# Altium schematic sheet Kontrollerkort_top.SchDoc(Kontrollerkort_top)
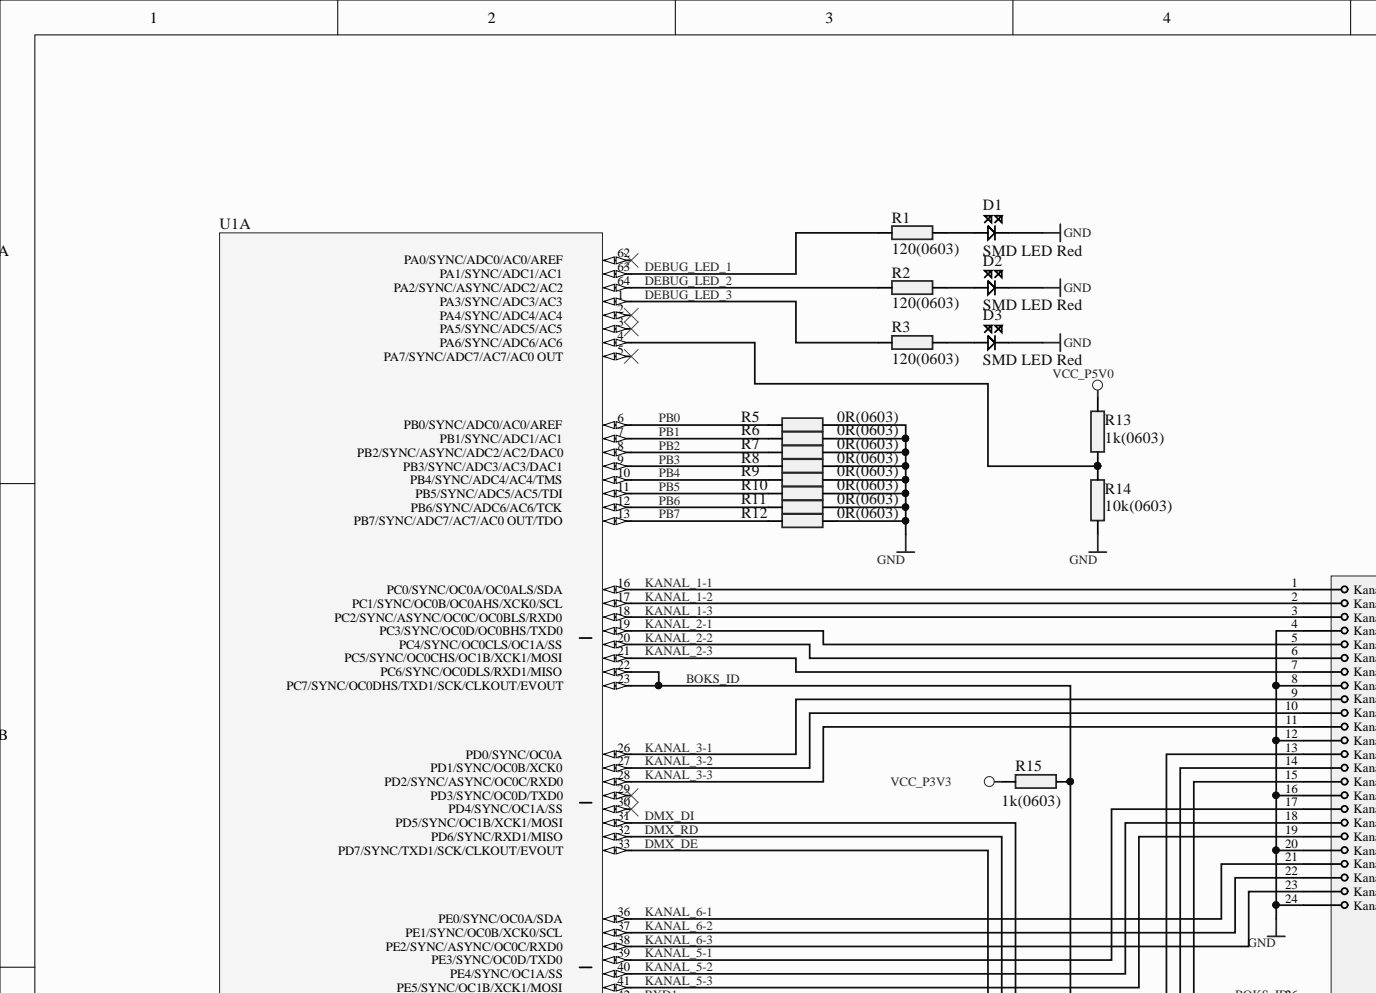
[diagram p0: Kontrollerkort_top.SchDoc, top left]
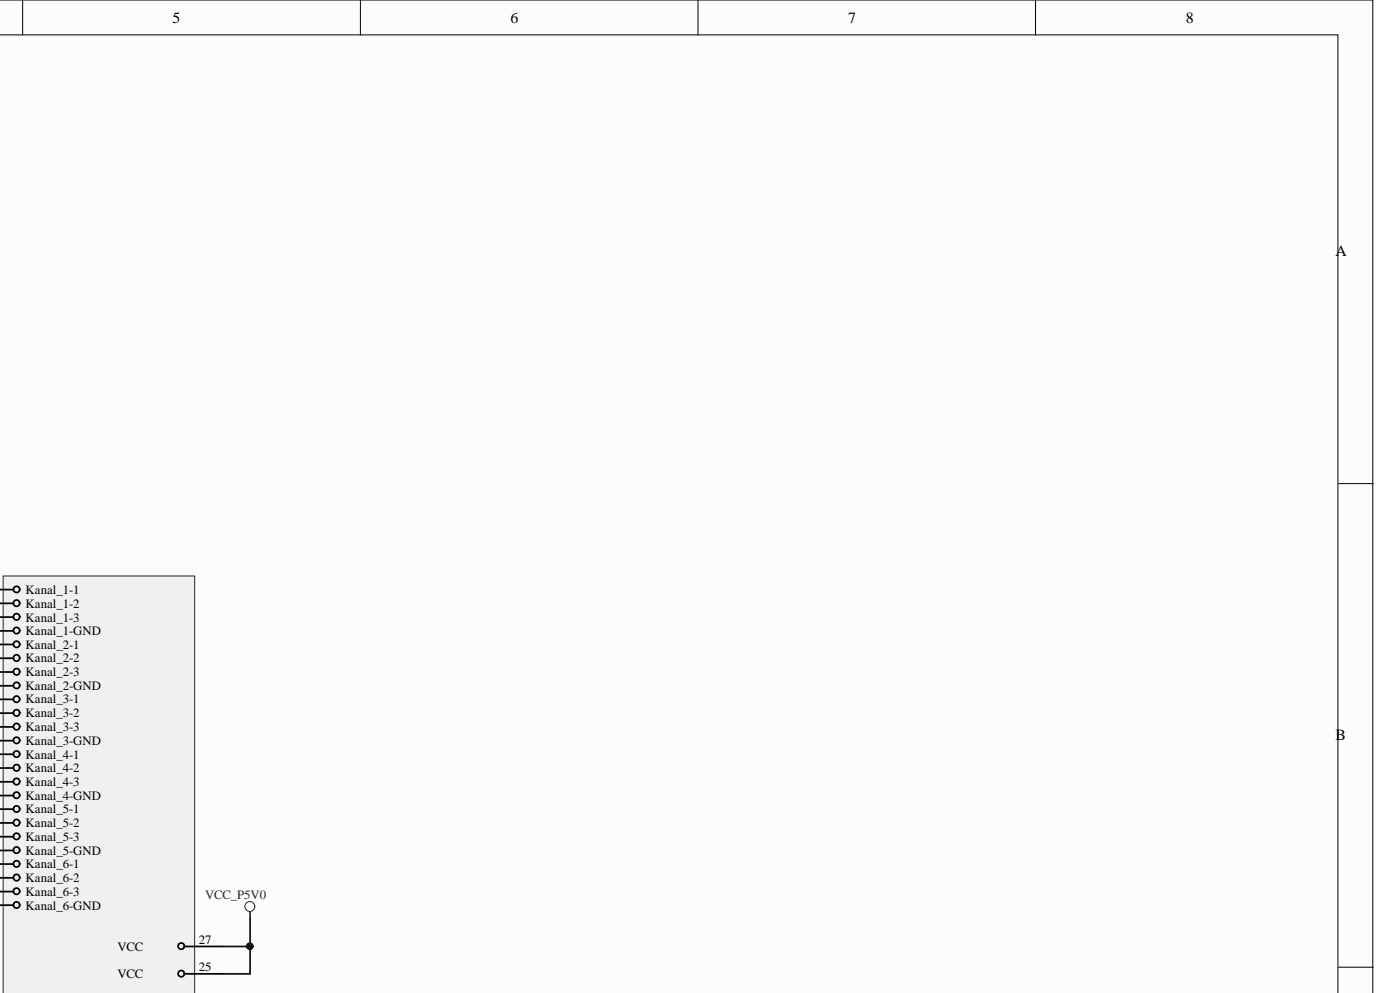
[diagram p1: Kontrollerkort_top.SchDoc, top right]
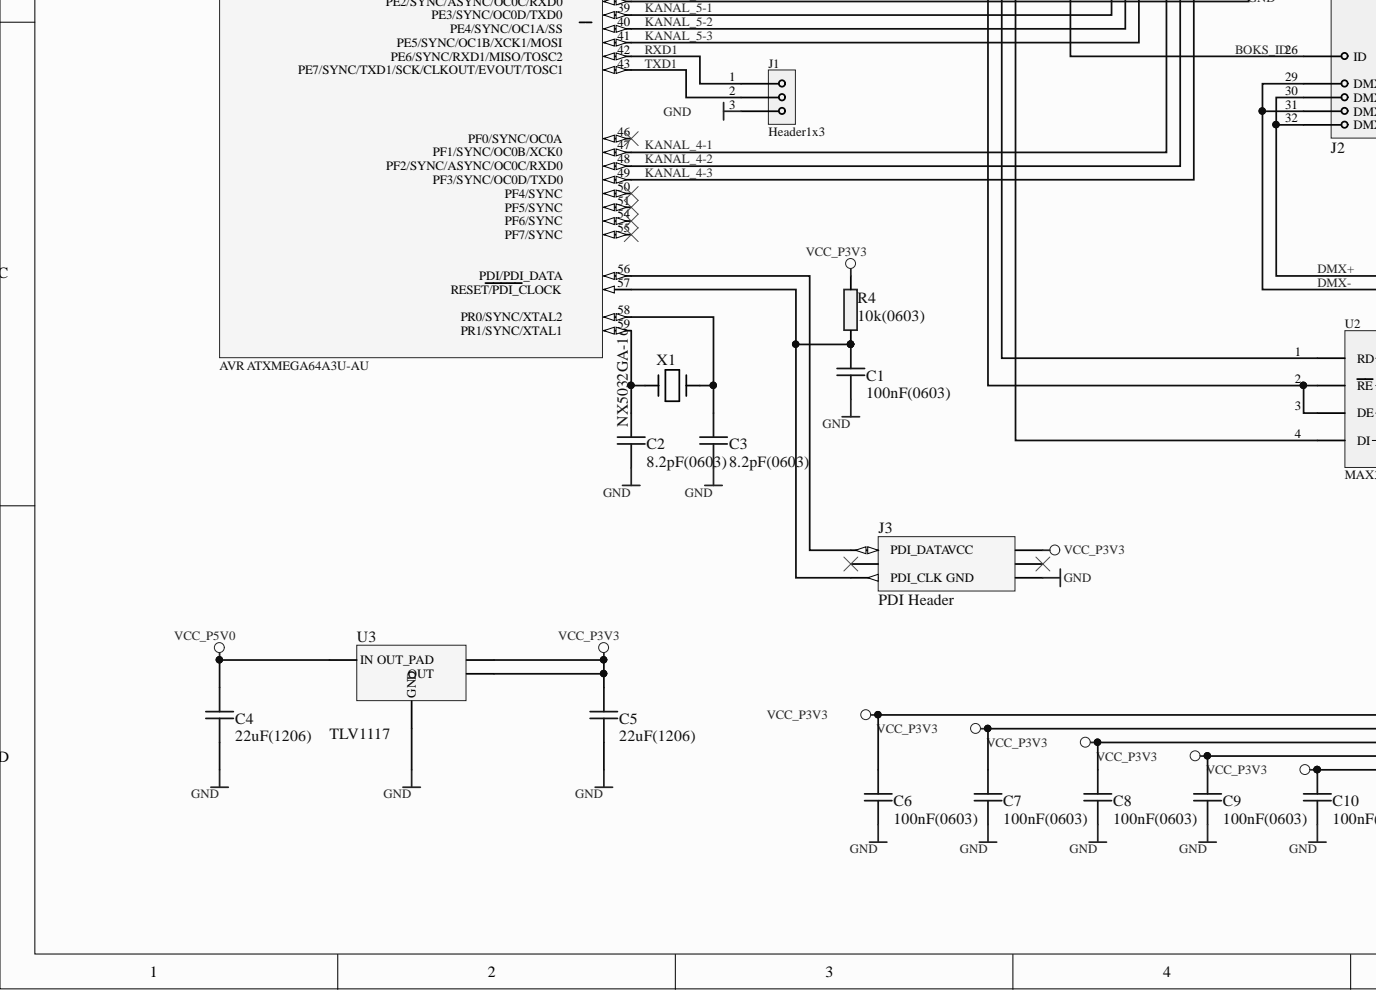
[diagram p2: Kontrollerkort_top.SchDoc, bottom left]
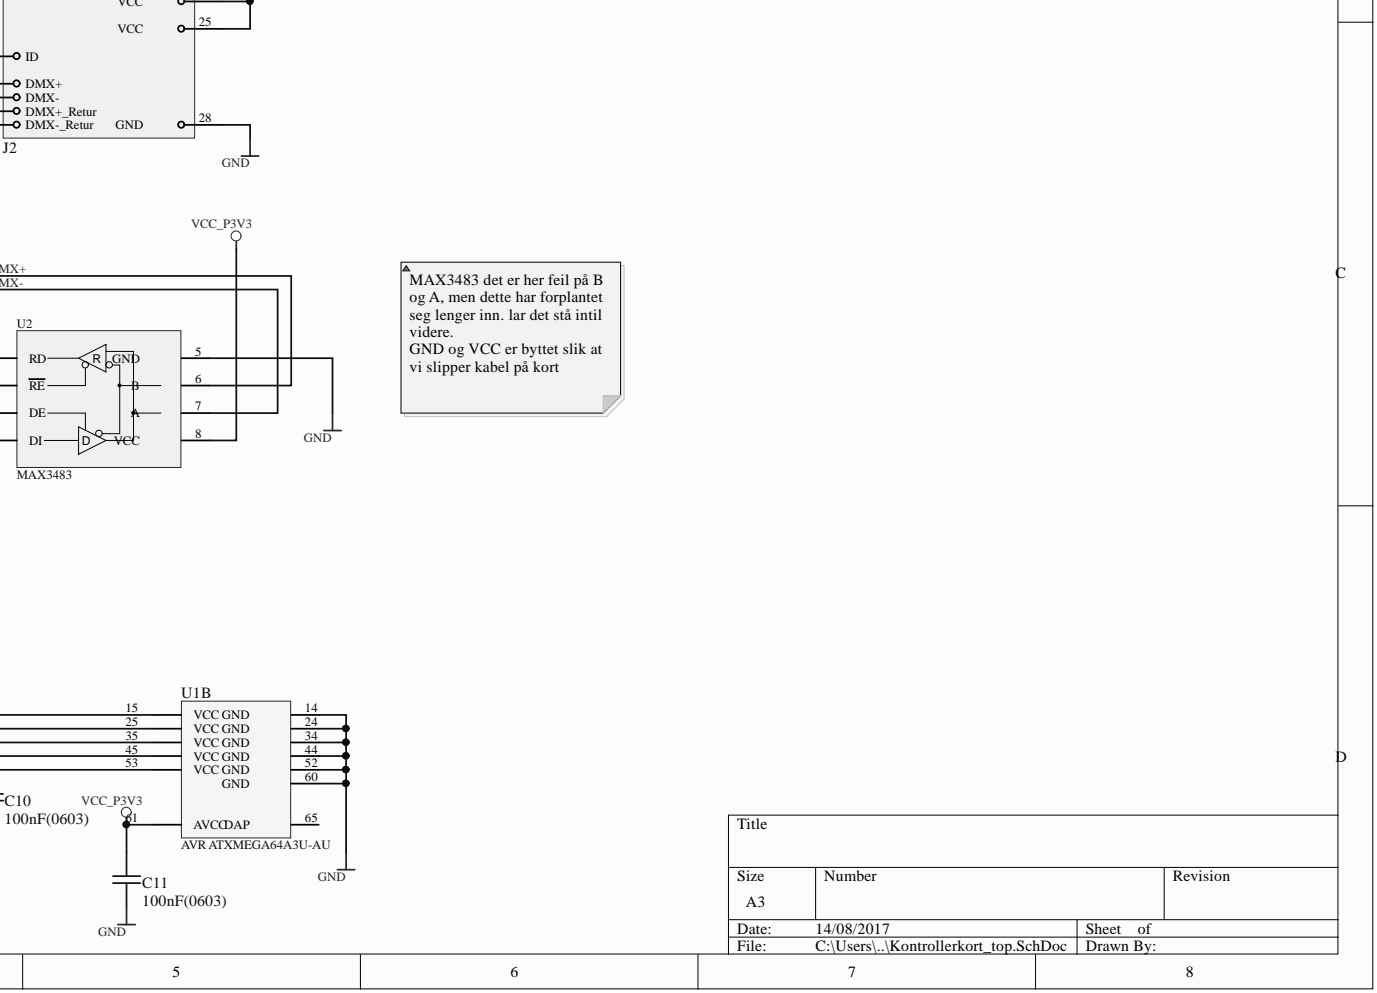
[diagram p3: Kontrollerkort_top.SchDoc, bottom right]

## Parts
C1  100nF(0603)  [CAPC0603(1608)55_N]
C2  8.2pF(0603)  [CAPC0603(1608)55_N]
C3  8.2pF(0603)  [CAPC0603(1608)55_N]
C4  22uF(1206)  [CAPC1206(3216)170_N]
C5  22uF(1206)  [CAPC1206(3216)170_N]
C6  100nF(0603)  [CAPC0603(1608)55_N]
C7  100nF(0603)  [CAPC0603(1608)55_N]
C8  100nF(0603)  [CAPC0603(1608)55_N]
C9  100nF(0603)  [CAPC0603(1608)55_N]
C10  100nF(0603)  [CAPC0603(1608)55_N]
C11  100nF(0603)  [CAPC0603(1608)55_N]
D1  SMD LED Red  [I_LEDC0603(1608)_N]
D2  SMD LED Red  [I_LEDC0603(1608)_N]
D3  SMD LED Red  [I_LEDC0603(1608)_N]
J1  Header1x3  [HDRV3W64P254_1X3_762X254X900B]
J2  Lysreklameparalellbusskontakt2015  [HDRRA32W64P254_2X16_4064X1260X600B]  (value not drawn)
J3  PDI Header  [HEADER_3x2]
R1  120(0603)  [RESC0603(1608)_N]
R2  120(0603)  [RESC0603(1608)_N]
R3  120(0603)  [RESC0603(1608)_N]
R4  10k(0603)  [RESC0603(1608)_N]
R5  0R(0603)  [RESC0603(1608)_N]
R6  0R(0603)  [RESC0603(1608)_N]
R7  0R(0603)  [RESC0603(1608)_N]
R8  0R(0603)  [RESC0603(1608)_N]
R9  0R(0603)  [RESC0603(1608)_N]
R10  0R(0603)  [RESC0603(1608)_N]
R11  0R(0603)  [RESC0603(1608)_N]
R12  0R(0603)  [RESC0603(1608)_N]
R13  1k(0603)  [RESC0603(1608)_N]
R14  10k(0603)  [RESC0603(1608)_N]
R15  1k(0603)  [RESC0603(1608)_N]
U1  AVR ATXMEGA64A3U-AU  [TQFP64]  (parts A,B)
U2  MAX3483  [SOIC-8-WIDE]
U3  TLV1117  [SOT230P700X180-4N]
X1  NX5032GA-16  [CRYSTAL-ABM7]

## Nets
GND: C1.1 C2.1 C3.1 C4.1 C5.1 C6.1 C7.1 C8.1 C9.1 C10.1 C11.1 D1.2 D2.2 D3.2 J1.3 J2.4(Kanal_1-GND) J2.8(Kanal_2-GND) J2.12(Kanal_3-GND) J2.16(Kanal_4-GND) J2.20(Kanal_5-GND) J2.24(Kanal_6-GND) J2.28 J3.6 R5.2 R6.2 R7.2 R8.2 R9.2 R10.2 R11.2 R12.2 R14.1 U1.14 U1.24 U1.34 U1.44 U1.52 U1.60 U2.5 U3.1(GND)
VCC_P3V3: C5.2 C6.2 C7.2 C8.2 C9.2 C10.2 C11.2 J3.2 R4.2 R15.2 U1.15(VCC_GND) U1.25(VCC_GND) U1.35(VCC_GND) U1.45(VCC_GND) U1.53(VCC_GND) U1.61(AVCC) U2.8 U3.2 U3.4
VCC_P5V0: C4.2 J2.25 J2.27 R13.2 U3.3(IN_OUT_PAD)
BOKS_ID: J2.26(ID) R15.1 U1.22 U1.23
DEBUG_LED_1: R1.1 U1.63
DEBUG_LED_2: R2.1 U1.64
DEBUG_LED_3: R3.1 U1.1
DMX+: J2.30(DMX-) J2.32(DMX-_Retur) U2.6
DMX-: J2.29(DMX+) J2.31(DMX+_Retur) U2.7
DMX_DE: U1.33 U2.2(RE) U2.3(DE)
DMX_DI: U1.31 U2.4(DI)
DMX_RD: U1.32 U2.1(RD)
KANAL_1-1: J2.1(Kanal_1-1) U1.16
KANAL_1-2: J2.2(Kanal_1-2) U1.17
KANAL_1-3: J2.3(Kanal_1-3) U1.18
KANAL_2-1: J2.5(Kanal_2-1) U1.19
KANAL_2-2: J2.6(Kanal_2-2) U1.20
KANAL_2-3: J2.7(Kanal_2-3) U1.21
KANAL_3-1: J2.9(Kanal_3-1) U1.26
KANAL_3-2: J2.10(Kanal_3-2) U1.27
KANAL_3-3: J2.11(Kanal_3-3) U1.28
KANAL_4-1: J2.13(Kanal_4-1) U1.47
KANAL_4-2: J2.14(Kanal_4-2) U1.48
KANAL_4-3: J2.15(Kanal_4-3) U1.49
KANAL_5-1: J2.17(Kanal_5-1) U1.39
KANAL_5-2: J2.18(Kanal_5-2) U1.40
KANAL_5-3: J2.19(Kanal_5-3) U1.41
KANAL_6-1: J2.21(Kanal_6-1) U1.36
KANAL_6-2: J2.22(Kanal_6-2) U1.37
KANAL_6-3: J2.23(Kanal_6-3) U1.38
PB0: R5.1 U1.6
PB1: R6.1 U1.7
PB2: R7.1 U1.8
PB3: R8.1 U1.9
PB4: R9.1 U1.10
PB5: R10.1 U1.11
PB6: R11.1 U1.12
PB7: R12.1 U1.13
RXD1: J1.1 U1.42
TXD1: J1.2 U1.43
NetC1_2: C1.2 J3.5 R4.1 U1.57
NetC2_2: C2.2 U1.59 X1.1
NetC3_2: C3.2 U1.58 X1.2
NetD1_1: D1.1 R1.2
NetD2_1: D2.1 R2.2
NetD3_1: D3.1 R3.2
NetJ3_1: J3.1(PDI_DATAVCC) U1.56
NetR13_1: R13.1 R14.2 U1.4

Not connected: J3 3 4; U1 2 3 5 29 30 46 50 51 54 55 62 65

## Library data of the parts
C1 C6-C11 = 100nF(0603): 100nF 0603 5% X7R 50V; Database Table Name: altium_Kondensatorer; id: 3028; nokkelord: Kondensator, Capacitor, CAP; pakke_opprettet_av: 815; pakketype: 93; pris: 1; Component Kind: Standard; Library Name: OVLib.SVNDbLib; navn: 100nF(0603); pakke_opprettet: 18.02.2015 14:18:13; symbol_opprettet: 14.11.2014 20:19:34; symbol_opprettet_av: 815
C2 C3 = 8.2pF(0603): 0603 5% NP0 50V; Database Table Name: altium_Kondensatorer; id: 3032; nokkelord: Kondensator, Capacitor, CAP; pakke_opprettet_av: 815; pakketype: 93; pris: 1; Component Kind: Standard; Library Name: OVLib.SVNDbLib; navn: 8.2pF(0603); pakke_opprettet: 18.02.2015 14:18:13; symbol_opprettet: 14.11.2014 20:19:34; symbol_opprettet_av: 815
C4 C5 = 22uF(1206): 1206 10% Tantalum 10V; Database Table Name: altium_Kondensatorer; id: 3049; leverandor: Farnell; leverandor_varenr: 1457420; nokkelord: Capacitor Cap Kondis; pakke_opprettet_av: 815; pakketype: 95; pris: 3; produsent: Kemet; Component Kind: Standard; Library Name: OVLib.SVNDbLib; navn: 22uF(1206); pakke_opprettet: 11.02.2015 13:21:22; symbol_opprettet: 14.11.2014 20:19:34; symbol_opprettet_av: 815
D1-D3 = SMD LED Red: SMD; antall: 50; Database Table Name: altium; dybde: 2; hylle: 13; id: 2209; kolonne: 0; lager_type: Fremlager; leverandor: Farnell; leverandor_varenr: 8554641; pakke_opprettet_av: 815; pakketype: 93; pris: 1; produsent: Avago; rom: OV; Component Kind: Standard; Library Name: OVLib.SVNDbLib; navn: SMD LED Red; nokkelord: SMD; pakke_opprettet: 06.07.2014 18:55:44; rad: 0; symbol_opprettet: 06.07.2014 18:59:47; symbol_opprettet_av: 815
J1 = Header 1x3: 2,54mm pitch; Database Table Name: altium; id: 2430; pakke_opprettet_av: 815; pakketype: 92; pris: 2; Component Kind: Standard; Library Name: OVLib.SVNDbLib; navn: Header 1x3; pakke_opprettet: 13.11.2014 12:31:03; symbol_opprettet: 13.11.2014 10:59:05; symbol_opprettet_av: 815
J3 = PDI-HEADER: PDI Xmega programming header; Database Table Name: altium; id: 2407; leverandor: Farnell; leverandor_varenr: Derp; pakke_opprettet_av: 774; pakketype: 92; pris: 3; produsent: OV; Component Kind: Standard; Library Name: OVLib.SVNDbLib; navn: PDI-HEADER; nokkelord: PDI Xmega programming; pakke_opprettet: 23.08.2014 13:37:50; symbol_opprettet: 23.08.2014 13:38:30; symbol_opprettet_av: 774
R1-R3 = 3050: 120R 0603 0.1W 1% Thick film resistor; Database Table Name: altium_Motstander; pakke_opprettet_av: 815; pakketype: 93; pris: 0; symbol_opprettet_av: oystesm; Component Kind: Standard; id: 3050; Library Name: OVLib.SVNDbLib; navn: 120(0603); nokkelord: Resistor; pakke_opprettet: 08.07.2014 21:15:30; symbol_opprettet: 08.07.2014 21:16:33
R4 = 2444: 10k 0603 0.1W 1% Thick film resistor; antall: 100; Database Table Name: altium_Motstander; dybde: 72; hylle: 6; kolonne: 0; lager_type: Fremlager; nokkelord: Resistor Motstand; pakke_opprettet_av: 815; pakketype: 93; rad: -1; rom: OV; symbol_opprettet_av: oystesm; Component Kind: Standard; id: 2444; Library Name: OVLib.SVNDbLib; navn: 10k(0603); pakke_opprettet: 08.07.2014 21:15:30; pris: 0; symbol_opprettet: 08.07.2014 21:16:33
R5-R12 = 3186: 0.1W 1% Thick film resistor; Database Table Name: altium_Motstander; nokkelord: Resistor Motstand Jumper Lask; pakke_opprettet_av: 815; pakketype: 93; pris: 0; produsent: Multikomp; symbol_opprettet_av: oystesm; Component Kind: Standard; id: 3186; Library Name: OVLib.SVNDbLib; navn: 0R(0603); pakke_opprettet: 08.07.2014 21:15:30; symbol_opprettet: 08.07.2014 21:16:33
R13 = 3029: 0603 0.1W 1% Thick film resistor; Database Table Name: altium_Motstander; leverandor: Farnell; leverandor_varenr: 2447272; nokkelord: Resistor Motstand; pakke_opprettet_av: 815; pakketype: 93; pris: 0; produsent: Multikomp; symbol_opprettet_av: oystesm; Component Kind: Standard; id: 3029; Library Name: OVLib.SVNDbLib; navn: 1k(0603); pakke_opprettet: 08.07.2014 21:15:30; symbol_opprettet: 08.07.2014 21:16:33
R14 = 2444: 10k 0603 0.1W 1% Thick film resistor; antall: 100; Database Table Name: altium_Motstander; dybde: 72; hylle: 6; kolonne: 0; lager_type: Fremlager; leverandor: Farnell; leverandor_varenr: 2447230; nokkelord: Resistor Motstand; pakke_opprettet_av: 815; pakketype: 93; produsent: Multikomp; rad: -1; rom: OV; symbol_opprettet_av: oystesm; Component Kind: Standard; id: 2444; Library Name: OVLib.SVNDbLib; navn: 10k(0603); pakke_opprettet: 08.07.2014 21:15:30; pris: 0; symbol_opprettet: 08.07.2014 21:16:33
R15 = 3029: 0603 0.1W 1% Thick film resistor; Database Table Name: altium_Motstander; nokkelord: Resistor Motstand; pakke_opprettet_av: 815; pakketype: 93; pris: 0; produsent: Multikomp; symbol_opprettet_av: oystesm; Component Kind: Standard; id: 3029; Library Name: OVLib.SVNDbLib; navn: 1k(0603); pakke_opprettet: 08.07.2014 21:15:30; symbol_opprettet: 08.07.2014 21:16:33
U1 = AVR ATXMEGA64A3U-AU: Mikrokontroller; antall: 40; Database Table Name: altium; dybde: 0; id: 2105; kolonne: 1; lager_type: Fremlager; pakke_opprettet_av: 815; pakketype: 45; produsent: Atmel; rad: 4; rom: OV; Component Kind: Standard; hylle: 0; Library Name: OVLib.SVNDbLib; navn: AVR ATXMEGA64A3U-AU; pakke_opprettet: 13.07.2014 19:45:53; pris: 0; symbol_opprettet: 07.05.2015 23:14:48; symbol_opprettet_av: 815
U2 = MAX3483: 3.3V-Powered, 10Mbps and Slew-Rate-Limited True RS-485/RS-422 Transceivers; antall: 40; Database Table Name: altium; dybde: 0; hylle: 2; id: 3048; kolonne: 4; lager_type: Fremlager; leverandor: Farnell; leverandor_varenr: 1296640; nokkelord: RS485; pakke_opprettet_av: 815; pakketype: 53; pris: 22; produsent: Exar; rom: OV; Component Kind: Standard; Library Name: OVLib.SVNDbLib; navn: MAX3483; pakke_opprettet: 28.06.2014 18:39:37; rad: 4; symbol_opprettet: 07.05.2015 21:29:51; symbol_opprettet_av: 815
U3 = TLV1117: 3,3V Low dropout regulator; antall: 10; Database Table Name: altium; dybde: 0; hylle: 9; id: 2386; lager_type: Fremlager; leverandor: Farnell; leverandor_varenr: 1494942; nokkelord: ldo; pakke_opprettet_av: 774; pakketype: 77; pris: 5; produsent: Texas Instruments; rad: 2; rom: OV; Component Kind: Standard; kolonne: 0; Library Name: OVLib.SVNDbLib; navn: TLV1117; pakke_opprettet: 11.07.2014 22:00:23; symbol_opprettet: 11.07.2014 22:01:42; symbol_opprettet_av: 774
X1 = NX5032GA-16: Crystal 16 MHz; antall: 42; Database Table Name: altium; dybde: 1; hylle: 2; id: 1594; kolonne: 3; lager_type: Fremlager; leverandor: DigiKey; leverandor_varenr: 644-1135-1-ND; nokkelord: xtal, oscillator; pakke_opprettet_av: 774; pakketype: 101; pris: 5; produsent: NDK; rad: 0; rom: OV; Component Kind: Standard; Library Name: OVLib.SVNDbLib; navn: NX5032GA-16; pakke_opprettet: 23.08.2014 20:10:40; symbol_opprettet: 23.08.2014 20:23:20; symbol_opprettet_av: 774
J2 = Lysreklameparalellbusskontakt2015: Component Kind: Standard; Library Name: Lysreklameparalellbusskontakt2015.SchLib
